# Altium schematic sheet Swich_PSU.SchDoc(Swich_PSU)
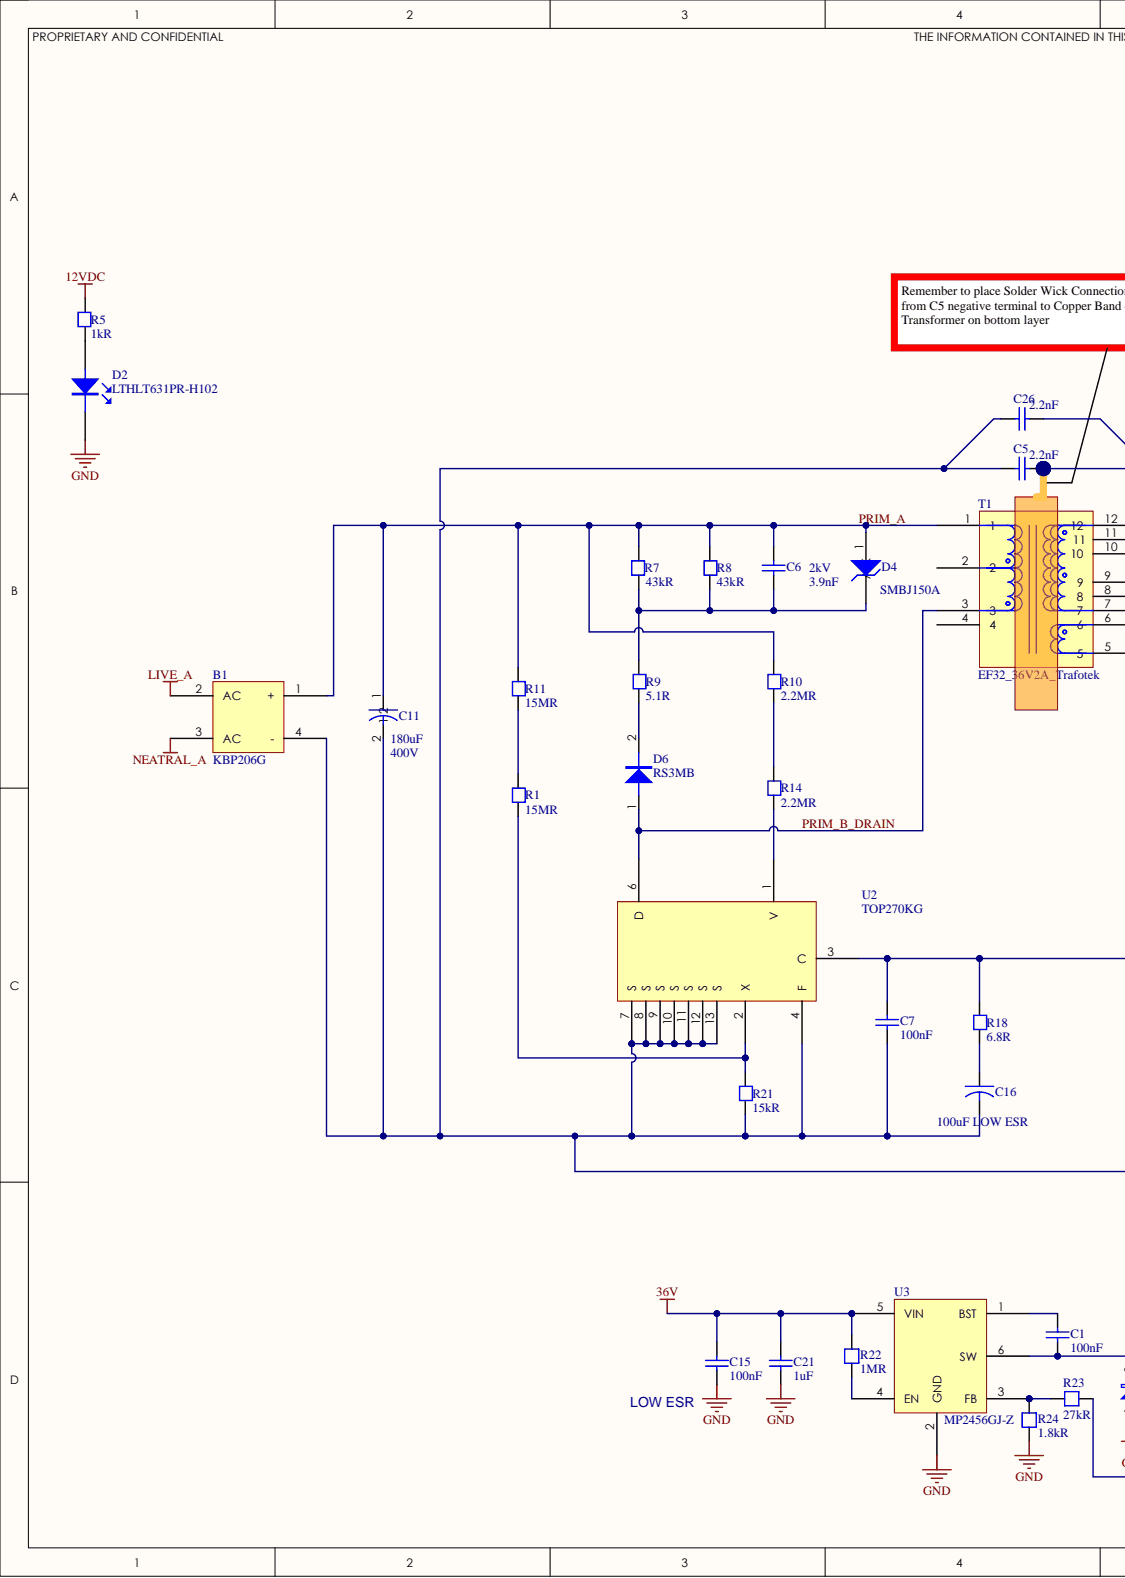
[diagram p0: Swich_PSU.SchDoc, left]
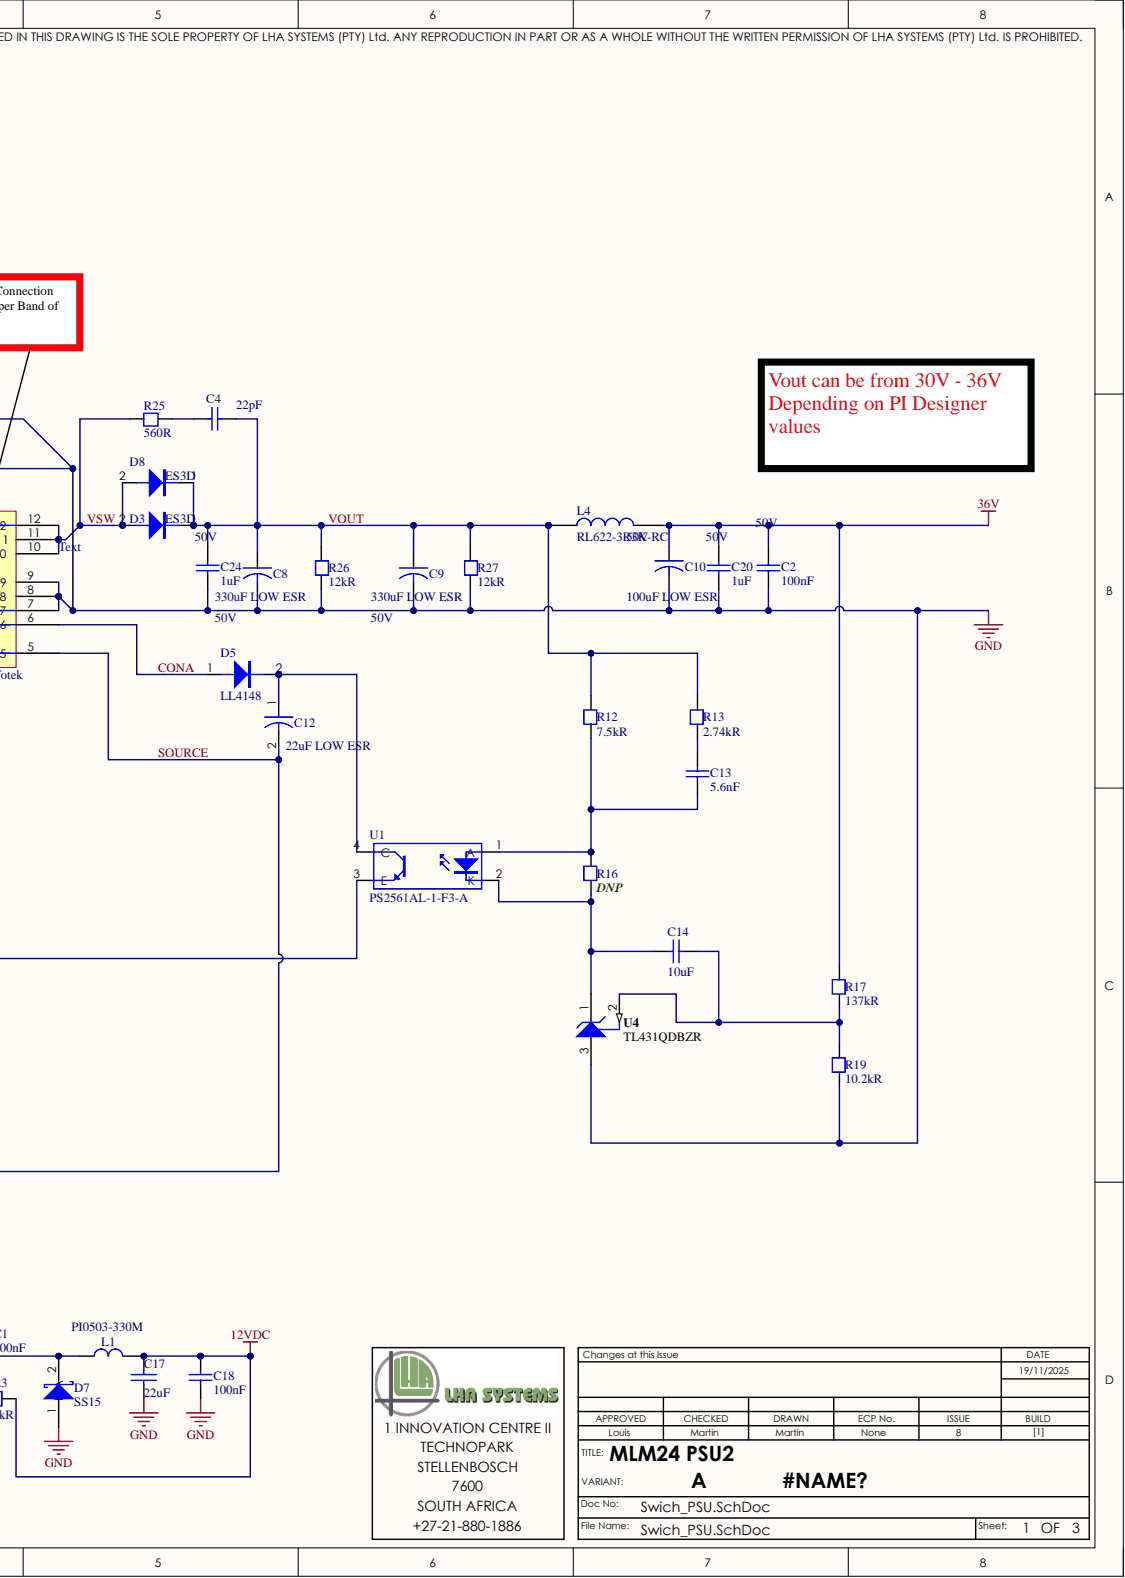
[diagram p1: Swich_PSU.SchDoc, right]

## Parts
B1  KBP206G  [KBP]
C1  100nF  [0805_OCTAL]
C2  100nF  Voltage Rated: 50V  [0805_OCTAL]
C4  22pF  [1206 - OCTO]
C5  2.2nF  [RAD_H15.5mmxP10mm]
C6  3.9nF  Voltage - Rated: 2kV  [1210 HIGH]
C7  100nF  [0805_OCTAL]
C8  330uF LOW ESR  Voltage Rated: 50V  [RAD-5R-21H-5P]
C9  330uF LOW ESR  Voltage Rated: 50V  [RAD-5R-21H-5P]
C10  100uF LOW ESR  Voltage Rated: 50V  [RAD-4R-13H-3.5P]
C11  180uF  Voltage Rated: 400V  [RAD-9.5R-42H-7.5P]
C12  22uF LOW ESR  [RAD-4R-13H-3.5P]
C13  5.6nF  [0805_OCTAL]
C14  10uF  [1206 - OCTO]
C15  100nF  [0805_OCTAL]
C16  100uF LOW ESR  [RAD-4R-13H-3.5P]
C17  22uF  [1210]
C18  100nF  [0805_OCTAL]
C20  1uF  Voltage Rated: 50V  [1206 - OCTO]
C21  1uF  [1206 - OCTO]
C24  1uF  Voltage Rated: 50V  [1206 - OCTO]
C26  2.2nF  [RAD_H15.5mmxP10mm]
D2  LTHLT631PR-H102  [SUNLED]
D3  ES3D  [DO-214AB-SMC]
D4  SMBJ150A  [SMB/P4.5]
D5  LL4148  [MLL34]
D6  RS3MB  [SMB/P4.5]
D7  SS15  [DO-214AC-2]
D8  ES3D  [DO-214AB-SMC]
L1  PI0503-330M  [PI0503]  (value not drawn)
L4  RL622-3R3K-RC  [RLB0914]
R1  15MR  [1206 - OCTO]
R5  1kR  [1206 - OCTO]
R7  43kR  [AXIAL-FMP200-STANDUP]
R8  43kR  [AXIAL-FMP200-STANDUP]
R9  5.1R  [1206 - OCTO]
R10  2.2MR  [1206 - OCTO]
R11  15MR  [1206 - OCTO]
R12  7.5kR  [1206 - OCTO]
R13  2.74kR  [1206 - OCTO]
R14  2.2MR  [1206 - OCTO]
R16  DNP  [0805_OCTAL]
R17  137kR  [1206 - OCTO]
R18  6.8R  [1206 - OCTO]
R19  10.2kR  [1206 - OCTO]
R21  15kR  [1206 - OCTO]
R22  1MR  [0603_OCTAL]
R23  27kR  [0603_OCTAL]
R24  1.8kR  [0603_OCTAL]
R25  560R  [1206 - OCTO]
R26  12kR  [1206]
R27  12kR  [1206]
T1  EF32_36V2A_Trafotek  [EF32_36V2A_TRAFOTEK]
U1  PS2561AL-1-F3-A  [4SMD-GULL-WING]
U2  TOP270KG  [eSOP-12B-K-Package]
U3  MP2456GJ-Z  [TSOT23-6]
U4  TL431QDBZR  [DBZ0003A_N]

## Nets
12VDC: C17.2 C18.1 L1.2 R5.1 R23.1
36V: C2.1 C10.1 C15.1 C20.2 C21.2 L4.2 R17.1 R22.1 U3.5(VIN)
GND: C2.2 C5.2 C8.2 C9.2 C10.2 C15.2 C17.1 C18.2 C20.1 C21.1 C24.1 C26.2 D2.2 D7.1 R19.2 R24.2 R26.1 R27.1 T1.7 T1.8 T1.9 U3.2(GND) U4.3
LIVE_A: B1.2(AC)
NEATRAL_A: B1.3(AC)
CONA: D5.1 T1.6
PRIM_A: B1.1(+) C6.2 C11.1 D4.1 R7.1 R8.2 R10.2 R11.2 T1.1
PRIM_B_DRAIN: D6.1 T1.3 U2.6(D)
SOURCE: B1.4(-) C5.1 C7.2 C11.2 C12.2 C16.2 C26.1 R21.1 T1.5 U2.4(F) U2.7(S) U2.8(S) U2.9(S) U2.10(S) U2.11(S) U2.12(S) U2.13(S)
VOUT: C4.2 C8.1 C9.1 C24.2 D3.1 D8.1 L4.1 R12.1 R13.1 R26.2 R27.2
VSW: D3.2 D8.2 R25.1 T1.10 T1.11 T1.12
NetC1_1: C1.1 U3.1(BST)
NetC1_2: C1.2 D7.2 L1.1 U3.6(SW)
NetC4_1: C4.1 R25.2
NetC6_1: C6.1 D4.2 R7.2 R8.1 R9.2
NetC7_1: C7.1 R18.2 U1.3(E) U2.3(C)
NetC12_1: C12.1 D5.2 U1.4(C)
NetC13_1: C13.1 R13.2
NetC13_2: C13.2 R12.2 R16.1 U1.1(A)
NetC14_1: C14.1 R16.2 U1.2(K) U4.1
NetC14_2: C14.2 R17.2 R19.1 U4.2
NetC16_1: C16.1 R18.1
NetD2_1: D2.1 R5.2
NetD6_2: D6.2 R9.1
NetR1_1: R1.1 R21.2 U2.2(X)
NetR1_2: R1.2 R11.1
NetR10_1: R10.1 R14.2
NetR14_1: R14.1 U2.1(V)
NetR22_2: R22.2 U3.4(EN)
NetR23_2: R23.2 R24.1 U3.3(FB)

Not connected: T1 2 4
